# Altium schematic sheet AEI FX300 - Controller.SchDoc("AEI FX300 - Controller")
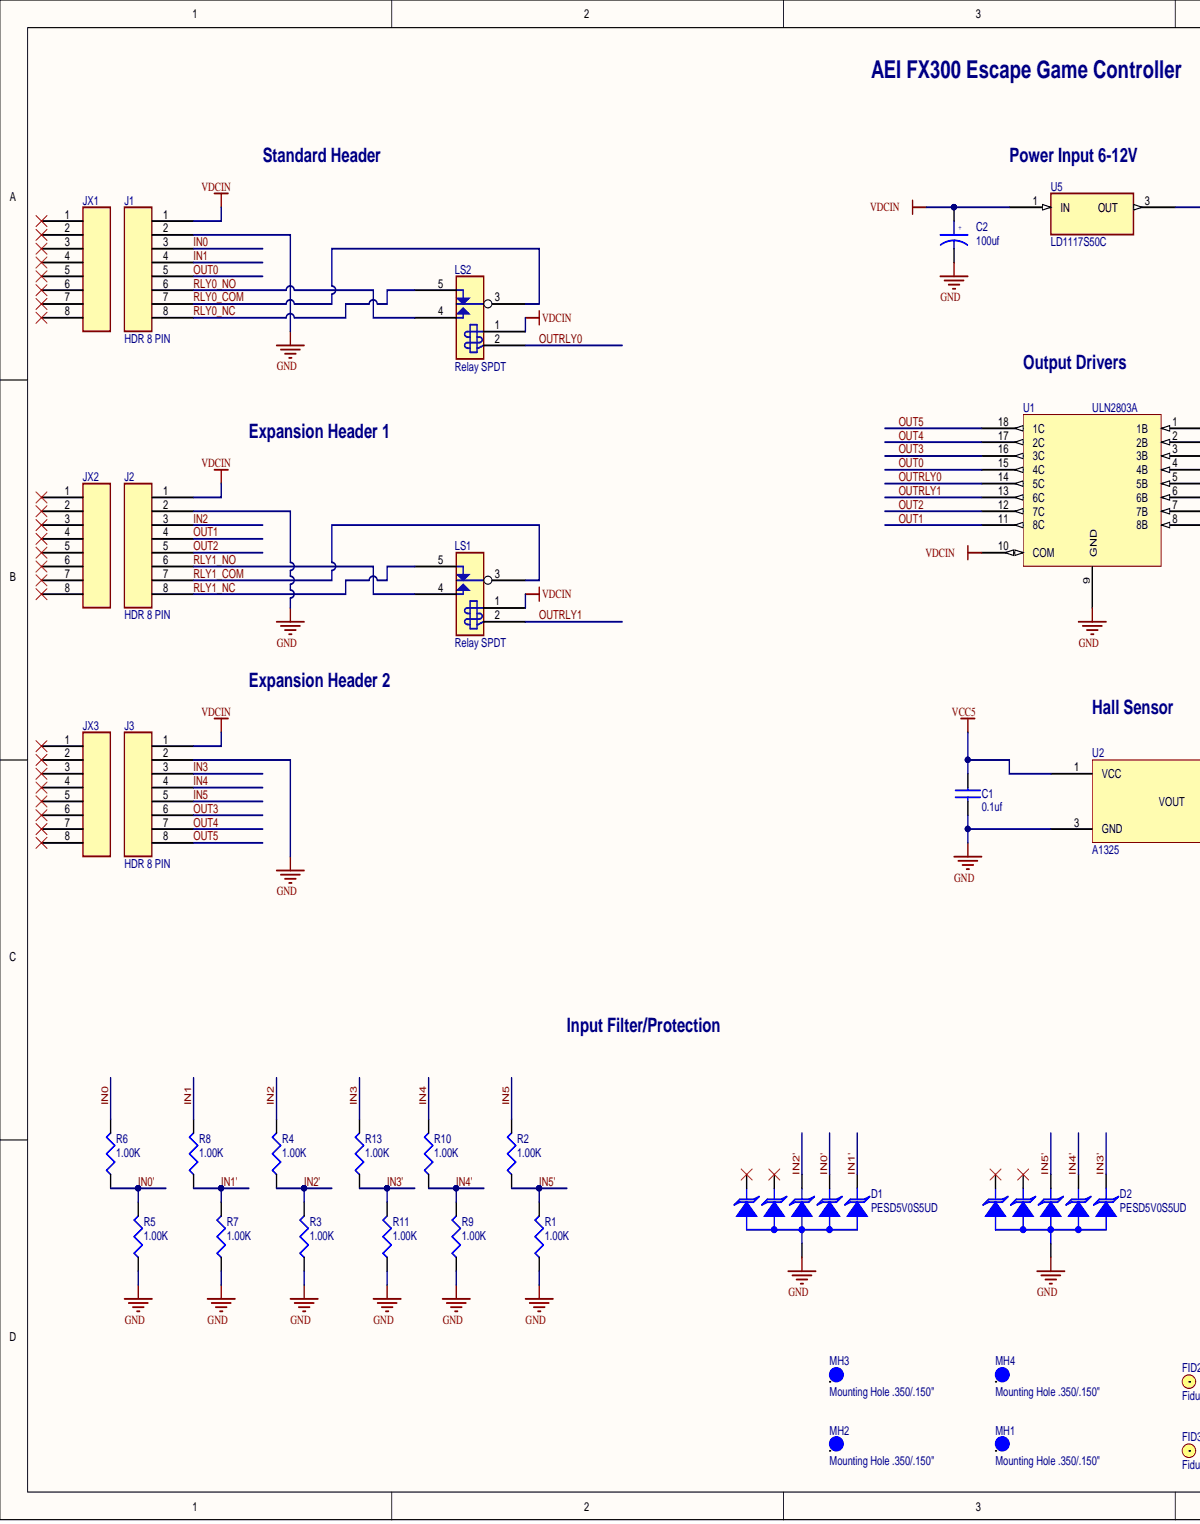
[diagram p0: AEI FX300 - Controller.SchDoc, left]
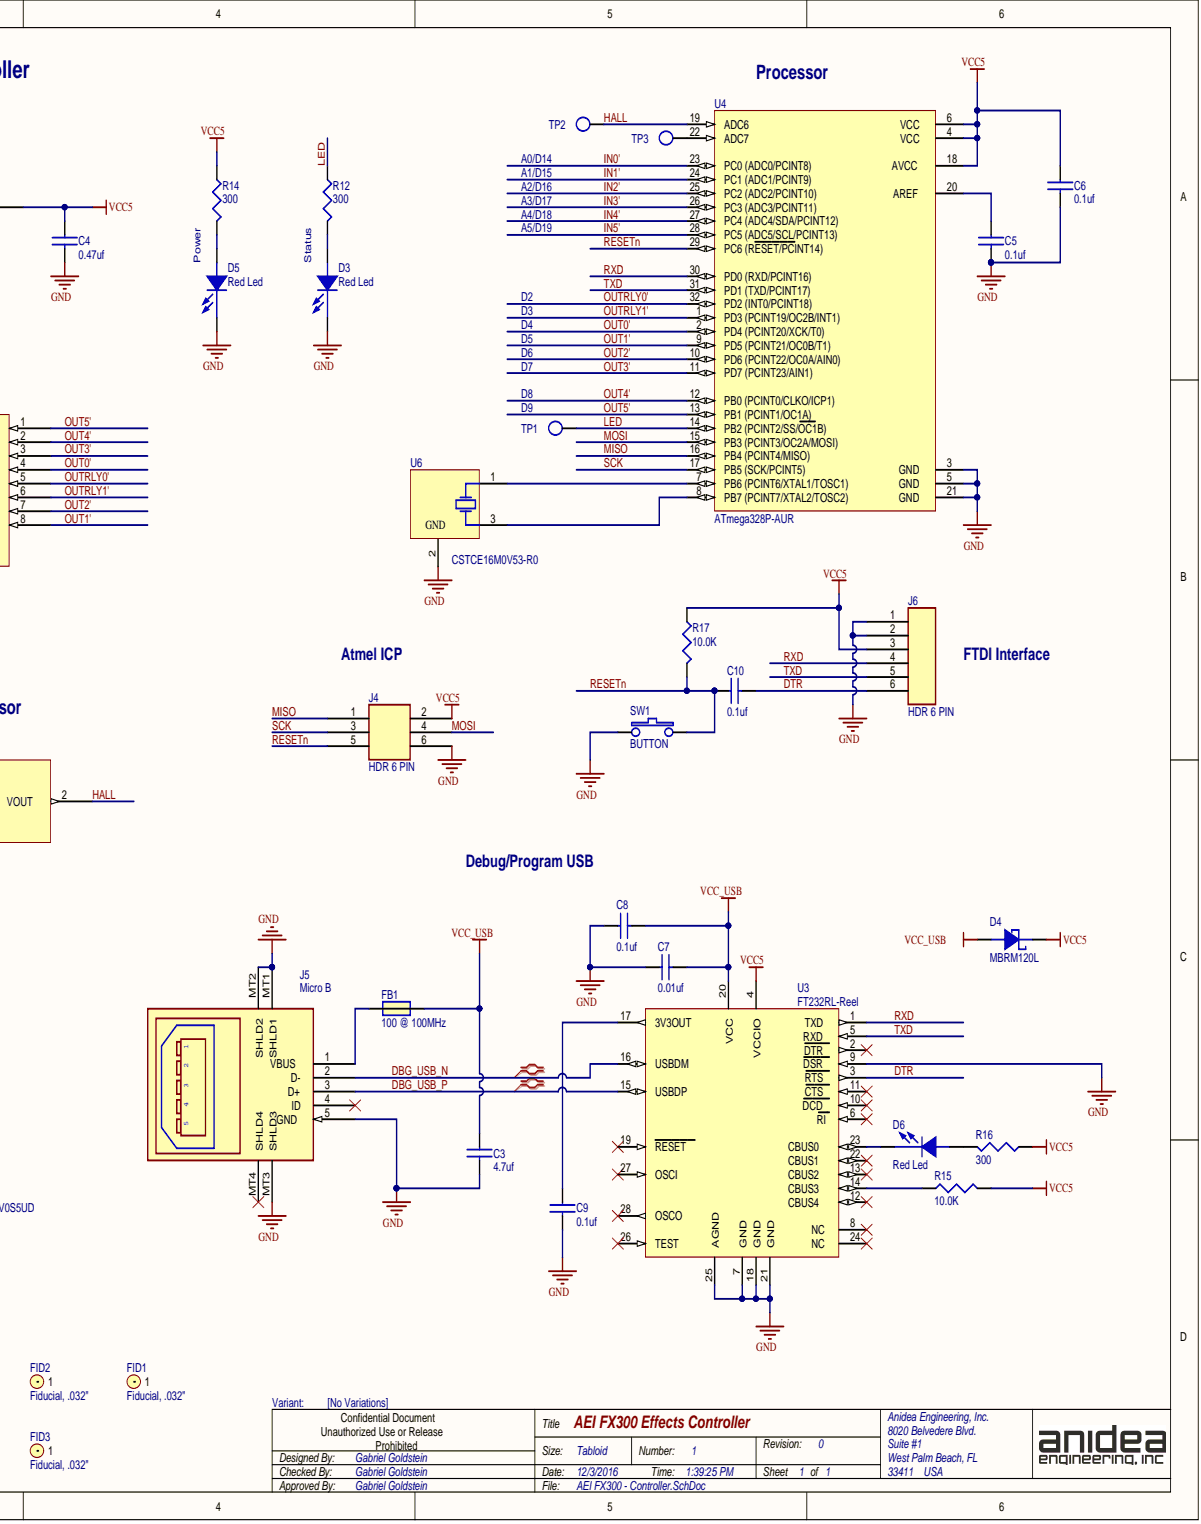
[diagram p1: AEI FX300 - Controller.SchDoc, right]

## Parts
C1  0.1uf  [SM/C_0603]
C2  100uf  [SM/C_6.3X5.5]
C3  4.7uf  [SM/C_0603]
C4  0.47uf  [SM/C_0603]
C5  0.1uf  [SM/C_0603]
C6  0.1uf  [SM/C_0603]
C7  0.01uf  [SM/C_0603]
C8  0.1uf  [SM/C_0603]
C9  0.1uf  [SM/C_0603]
C10  0.1uf  [SM/C_0603]
D1  PESD5V0S5UD  [SOT457]
D2  PESD5V0S5UD  [SOT457]
D3  Red Led  [SM/D_1206]
D4  MBRM120L  [POWERMITE]
D5  Red Led  [SM/D_1206]
D6  Red Led  [SM/D_1206]
FB1  100 @ 100MHz  [SM/I_0603]
FID1  Fiducial, .032"  [FID32]  (no BOM)
FID2  Fiducial, .032"  [FID32]  (no BOM)
FID3  Fiducial, .032"  [FID32]  (no BOM)
J1  HDR 8 PIN  [HDR/8/5.08MM/RA/1836244]
J2  HDR 8 PIN  [HDR/8/5.08MM/RA/1836244]
J3  HDR 8 PIN  [HDR/8/5.08MM/RA/1836244]
J4  HDR 6 PIN  [HDR/2X3/.1]
J5  Micro B  [USB/10118192-0001LF]
J6  HDR 6 PIN  [HDR/6/.1]
JX1  HDR 8 PIN  (value not drawn)
JX2  HDR 8 PIN  (value not drawn)
JX3  HDR 8 PIN  (value not drawn)
LS1  Relay SPDT  [RELAY/812H]
LS2  Relay SPDT  [RELAY/812H]
MH1  Mounting Hole .350/.150"  [MH.350/.150in]  (no BOM)
MH2  Mounting Hole .350/.150"  [MH.350/.150in]  (no BOM)
MH3  Mounting Hole .350/.150"  [MH.350/.150in]  (no BOM)
MH4  Mounting Hole .350/.150"  [MH.350/.150in]  (no BOM)
R1  1.00K  [SM/R_0603]
R2  1.00K  [SM/R_0603]
R3  1.00K  [SM/R_0603]
R4  1.00K  [SM/R_0603]
R5  1.00K  [SM/R_0603]
R6  1.00K  [SM/R_0603]
R7  1.00K  [SM/R_0603]
R8  1.00K  [SM/R_0603]
R9  1.00K  [SM/R_0603]
R10  1.00K  [SM/R_0603]
R11  1.00K  [SM/R_0603]
R12  300  [SM/R_0603]
R13  1.00K  [SM/R_0603]
R14  300  [SM/R_0603]
R15  10.0K  [SM/R_0603]
R16  300  [SM/R_0603]
R17  10.0K  [SM/R_0603]
SW1  BUTTON  [SWITCH4/PTS525_SM15]
TP1  TP  [VIA18]  (no BOM)
TP2  TP  [VIA18]  (no BOM)
TP3  TP  [VIA18]  (no BOM)
U1  ULN2803A  [SOIC18_12mmx8mm]
U2  A1325  [SOT23W]
U3  FT232RL-Reel  [SSOP-28_L]
U4  ATmega328P-AUR  [32A_M]
U5  LD1117S50C  [SOT223]
U6  CSTCE16M0V53-R0  [MURA-CSTCE_V-3_V]

## Nets
GND: C1.2 C2.2 C3.2 C4.2 C5.2 C6.2 C7.1 C8.1 C9.2 D1.2 D2.2 D3.2 D5.2 J1.2 J2.2 J3.2 J4.6 J5.5(GND) J5.MT1(SHLD1) J5.MT2(SHLD2) J5.MT3(SHLD3) J6.1 J6.2 R1.1 R3.1 R5.1 R7.1 R9.1 R11.1 SW1.1 U1.9(GND) U2.3 U3.7(GND) U3.9(DSR) U3.18(GND) U3.21(GND) U3.25(AGND) U4.3(GND) U4.5(GND) U4.21(GND) U5.2 U5.4 U6.2
VCC5: C1.1 C4.1 C6.1 D4.2 J4.2 J6.3 R14.1 R15.2 R16.1 R17.1 U2.1 U3.4(VCCIO) U4.4(VCC) U4.6(VCC) U4.18(AVCC) U5.3
VCC_USB: C3.1 C7.2 C8.2 D4.1 FB1.1 U3.20(VCC)
VDCIN: C2.1 J1.1 J2.1 J3.1 LS1.1 LS2.1 U1.10(COM) U5.1
DBG_USB_N: J5.2(D-) U3.16(USBDM)
DBG_USB_P: J5.3(D+) U3.15(USBDP)
DTR: C10.2 J6.6 U3.3(RTS)
HALL: TP2.1 U2.2 U4.19(ADC6)
IN0: J1.3 R6.2
IN0': D1.5 R5.2 R6.1 U4.23(PC0_(ADC0/PCINT8))
IN1: J1.4 R8.2
IN1': D1.6 R7.2 R8.1 U4.24(PC1_(ADC1/PCINT9))
IN2: J2.3 R4.2
IN2': D1.4 R3.2 R4.1 U4.25(PC2_(ADC2/PCINT10))
IN3: J3.3 R13.2
IN3': D2.6 R11.2 R13.1 U4.26(PC3_(ADC3/PCINT11))
IN4: J3.4 R10.2
IN4': D2.5 R9.2 R10.1 U4.27(PC4_(ADC4/SDA/PCINT12))
IN5: J3.5 R2.2
IN5': D2.4 R1.2 R2.1 U4.28(PC5_(ADC5/SCL/PCINT13))
LED: R12.1 TP1.1 U4.14(PB2_(PCINT2/SS/OC1B))
MISO: J4.1 U4.16(PB4_(PCINT4/MISO))
MOSI: J4.4 U4.15(PB3_(PCINT3/OC2A/MOSI))
OUT0: J1.5 U1.15(4C)
OUT0': U1.4(4B) U4.2(PD4_(PCINT20/XCK/T0))
OUT1: J2.4 U1.11(8C)
OUT1': U1.8(8B) U4.9(PD5_(PCINT21/OC0B/T1))
OUT2: J2.5 U1.12(7C)
OUT2': U1.7(7B) U4.10(PD6_(PCINT22/OC0A/AIN0))
OUT3: J3.6 U1.16(3C)
OUT3': U1.3(3B) U4.11(PD7_(PCINT23/AIN1))
OUT4: J3.7 U1.17(2C)
OUT4': U1.2(2B) U4.12(PB0_(PCINT0/CLKO/ICP1))
OUT5: J3.8 U1.18(1C)
OUT5': U1.1(1B) U4.13(PB1_(PCINT1/OC1A))
OUTRLY0: LS2.2 U1.14(5C)
OUTRLY0': U1.5(5B) U4.32(PD2_(INT0/PCINT18))
OUTRLY1: LS1.2 U1.13(6C)
OUTRLY1': U1.6(6B) U4.1(PD3_(PCINT19/OC2B/INT1))
RESETN: C10.1 J4.5 R17.2 SW1.2 U4.29(PC6_(RESET/PCINT14))
RLY0_COM: J1.7 LS2.3
RLY0_NC: J1.8 LS2.5
RLY0_NO: J1.6 LS2.4
RLY1_COM: J2.7 LS1.3
RLY1_NC: J2.8 LS1.5
RLY1_NO: J2.6 LS1.4
RXD: J6.4 U3.1(TXD) U4.30(PD0_(RXD/PCINT16))
SCK: J4.3 U4.17(PB5_(SCK/PCINT5))
TXD: J6.5 U3.5(RXD) U4.31(PD1_(TXD/PCINT17))
NetC5_1: C5.1 U4.20(AREF)
NetC9_1: C9.1 U3.17(3V3OUT)
NetD1_1: D1.1
NetD1_3: D1.3
NetD2_1: D2.1
NetD2_3: D2.3
NetD3_1: D3.1 R12.2
NetD5_1: D5.1 R14.2
NetD6_1: D6.1 R16.2
NetD6_2: D6.2 U3.23(CBUS0)
NetFB1_2: FB1.2 J5.1(VBUS)
NetFID1_1: FID1.1
NetFID2_1: FID2.1
NetFID3_1: FID3.1
NetJ5_4: J5.4(ID)
NetJ5_MT4: J5.MT4(SHLD4)
NetJX1_1: JX1.1
NetJX1_2: JX1.2
NetJX1_3: JX1.3
NetJX1_4: JX1.4
NetJX1_5: JX1.5
NetJX1_6: JX1.6
NetJX1_7: JX1.7
NetJX1_8: JX1.8
NetJX2_1: JX2.1
NetJX2_2: JX2.2
NetJX2_3: JX2.3
NetJX2_4: JX2.4
NetJX2_5: JX2.5
NetJX2_6: JX2.6
NetJX2_7: JX2.7
NetJX2_8: JX2.8
NetJX3_1: JX3.1
NetJX3_2: JX3.2
NetJX3_3: JX3.3
NetJX3_4: JX3.4
NetJX3_5: JX3.5
NetJX3_6: JX3.6
NetJX3_7: JX3.7
NetJX3_8: JX3.8
NetMH1_1: MH1.1
NetMH2_1: MH2.1
NetMH3_1: MH3.1
NetMH4_1: MH4.1
NetR15_1: R15.1 U3.14(CBUS3)
NetTP3_1: TP3.1 U4.22(ADC7)
NetU3_2: U3.2(DTR)
NetU3_6: U3.6(RI)
NetU3_8: U3.8(NC)
NetU3_10: U3.10(DCD)
NetU3_11: U3.11(CTS)
NetU3_12: U3.12(CBUS4)
NetU3_13: U3.13(CBUS2)
NetU3_19: U3.19(RESET)
NetU3_22: U3.22(CBUS1)
NetU3_24: U3.24(NC)
NetU3_26: U3.26(TEST)
NetU3_27: U3.27(OSCI)
NetU3_28: U3.28(OSCO)
NetU4_7: U4.7(PB6_(PCINT6/XTAL1/TOSC1)) U6.1
NetU4_8: U4.8(PB7_(PCINT7/XTAL2/TOSC2)) U6.3

## Library data of the parts
C1 C5 C6 C8-C10 = GRM188R71C104KA01: Cap, 0603, 0.1uf, 16V, 10%,; Mfg Part Number: GRM188R71C104KA01D; Database Table Name: AnideaParts; Mfg: Murata; Component Kind: Standard; ComponentLink1Description: Local; ComponentLink1URL: \\brak\public\pdf\Murata Cap PN.pdf; ComponentLink2Description: Web; Library Name: Anidea.SVNDbLib; Part Number: GRM188R71C104KA01; RoHS: True; Supplier 1: Digi-Key; Supplier Part Number 1: 490-1532-1-ND; Tolerance: 10%; Voltage: 16V
C2 = NACE101M16V6.3X5.5: Cap, SMT 6.3x5.5, 100uf, 16V, 20%, Al Ele; Mfg Part Number: UWX1C101MCL1GB; Database Table Name: AnideaParts; Mfg: Nichicon; Component Kind: Standard; ComponentLink1Description: Local; ComponentLink2Description: Web; Library Name: Anidea.SVNDbLib; Part Number: UWX1C101MCL1GB; RoHS: True; Tolerance: 20%; Voltage: 16V
C3 = GRM188R60J475KE19D: Cap, 0603, 4.7uf , 6.3V, 10%, X5R; Database Table Name: AnideaParts; Mfg: Murata; Component Kind: Standard; ComponentLink1Description: Local; ComponentLink1URL: \\brak\public\pdf\Murata Cap PN.pdf; ComponentLink2Description: Web; Library Name: Anidea.SVNDbLib; Mfg Part Number: GRM188R60J475KE19D; Part Number: GRM188R60J475KE19D; RoHS: True; Supplier 1: Digi-Key; Supplier Part Number 1: 490-3297-1-ND; Tolerance: 10%; Voltage: 6.3V
C4 = GRM188R61A474KA61: Cap, 0603, 0.47uf, 10V, 10%, X5R; Mfg Part Number: GRM188R61A474KA61D; Database Table Name: AnideaParts; Mfg: Murata; Component Kind: Standard; ComponentLink1Description: Local; ComponentLink1URL: \\newbrak\public\pdf\Murata Cap PN.pdf; ComponentLink2Description: Web; Library Name: Anidea.SVNDbLib; Part Number: GRM188R61A474KA61; RoHS: True; Supplier 1: Digi-Key; Supplier 2: TDK Corporation; Supplier 3: Digi-Key; Supplier Part Number 1: 490-1539-1-ND; Supplier Part Number 2: C1608X5R1A474K080AA; Supplier Part Number 3: 445-1320-1-ND; Tolerance: 10%; Voltage: 10V
C7 = GRM188R71H103KA01: Cap, 0603, .01uf, 50V, 10%,; Mfg Part Number: GRM188R71H103KA01D; Database Table Name: AnideaParts; Mfg: Murata; Component Kind: Standard; ComponentLink1Description: Local; ComponentLink1URL: \\brak\public\pdf\Murata Cap PN.pdf; ComponentLink2Description: Web; Library Name: Anidea.SVNDbLib; Part Number: GRM188R71H103KA01; RoHS: True; Supplier 1: Digi-Key; Supplier Part Number 1: 490-1512-1-ND; Tolerance: 10%; Voltage: 50V
D1 D2 = PESD5V0S5UD: TVS, ESD, 5 Diode Array, 5.0V, 30KV Pk, Unidirectional, SMT; Mfg Part Number: PESD5V0S5UD,115; Database Table Name: AnideaParts; Mfg: NXP; Rating: 200W; Component Kind: Standard; ComponentLink1Description: Local; ComponentLink1URL: \\newbrak\public\pdf\NXP PESDXS5UD_SER ESD ARRAY x5.pdf; ComponentLink2Description: Web; ComponentLink2URL: http://www.nxp.com/documents/data_sheet/PESDXS5UD_SER.pdf; Library Name: Anidea.SVNDbLib; Part Number: PESD5V0S5UD; RoHS: True; Supplier 1: Digi-Key; Supplier Part Number 1: 568-4142-1-ND; Voltage: 5.0V
D3 D5 D6 = BR1101W: Led, Red, 1206, Flat Top; Database Table Name: AnideaParts; Mfg: Stanley; Component Kind: Standard; ComponentLink1Description: Local; ComponentLink1URL: \\brak\public\pdf\Stanley 1101W.pdf; ComponentLink2Description: Web; Library Name: Anidea.SVNDbLib; Mfg Part Number: BR1101W; ModifiedDate: 12/3/2016; Part Number: BR1101W; RoHS: False; Supplier 1: Digi-Key; Supplier Part Number 1: 404-1042-1-ND
D4 = MBRM120L: Diode, Schottky, 1A, 20V; Mfg Part Number: MBRM120LT1G; Database Table Name: AnideaParts; Mfg: On Semi; Component Kind: Standard; ComponentLink1Description: Local; ComponentLink1URL: \\newbrak\public\pdf\OnSemi MBRM120L.pdf; ComponentLink2Description: Web; Library Name: Anidea.SVNDbLib; Part Number: MBRM120L; Rating: 1A; RoHS: True; Supplier 1: Digi-Key; Supplier Part Number 1: MBRM120LT1GOSCT-ND
FB1 = BLM18KG101TN1: EMI Bead, 0603, 100 OHM @ 100MHz, low DCR, Ferrite; Mfg Part Number: BLM18KG101TN1D; Database Table Name: AnideaParts; Mfg: Murata; Rating: 3A; Component Kind: Standard; ComponentLink1Description: Local; ComponentLink1URL: \\newbrak\Public\pdf\Murata BLM18K Ferrite Bead.pdf; ComponentLink2Description: Web; ComponentLink2URL: http://search.murata.co.jp/Ceramy/image/img/PDF/ENG/L0110S0100BLM18K.pdf; Library Name: Anidea.SVNDbLib; Part Number: BLM18KG101TN1; RoHS: True; Supplier 1: Digi-Key; Supplier 2: Murata; Supplier Part Number 1: 490-5448-1-ND; Supplier Part Number 2: BLM18KG101TN1D
FID1-FID3 = FID32: Fiducual, .032" Pad; Database Table Name: AnideaParts; ComponentLink1Description: Local; ComponentLink1URL: \\newbrak\public\pdf\; ComponentLink2Description: Web; Library Name: Anidea.SVNDbLib; Part Number: FID32; RoHS: False
J1-J3 = 1836244: Connector, Terminal Block, SIP, 8 pin, 5.08mm, Female, RA; Database Table Name: AnideaParts; Mfg: Phoenix Contact; Component Kind: Standard; ComponentLink1Description: Local; ComponentLink1URL: \\newbrak\public\pdf\Phoenix Contact 1836244.pdf; ComponentLink2Description: Web; ComponentLink2URL: http://eshop.phoenixcontact.com/phoenix/pdf.do?UID=1836244&general=usen; Library Name: Anidea.SVNDbLib; Mfg Part Number: 1836244; Part Number: 1836244; RoHS: True; Supplier 2: Mouser; Supplier Part Number 2: 651-1836244
J4 = PBC03DAAN: Header, Dual Row, 6 pin .1"; Database Table Name: AnideaParts; Mfg: Sullins Electronics; Component Kind: Standard; ComponentLink1Description: Local; ComponentLink1URL: \\newbrak\public\pdf\Sullins .1in Header.pdf; ComponentLink2Description: Web; ComponentLink2URL: http://www.sullinscorp.com/catalogs/97_Page78-79_HEADER_.100_2.54mm_MALE_BREAKAWAY.pdf; Library Name: Anidea.SVNDbLib; Mfg Part Number: PBC03DAAN; Part Number: PBC03DAAN; RoHS: True; Supplier 1: Digi-Key; Supplier Part Number 1: S2011E-03-ND
J5 = 10118192-0001LF: Connector, USB, Micro-B, Horizontal, SMT; Database Table Name: AnideaParts; Mfg: Amphenol FCI; Component Kind: Standard; ComponentLink1Description: Local; ComponentLink1URL: \\newbrak\public\pdf\Amphenol FCI 10118192.pdf; ComponentLink2Description: Web; ComponentLink2URL: http://portal.fciconnect.com/Comergent//fci/drawing/10118192.pdf; Library Name: Anidea.SVNDbLib; Mfg Part Number: 10118192-0001LF; Part Number: 10118192-0001LF; RoHS: True; Supplier 1: Digi-Key; Supplier Part Number 1: 609-4613-1-ND
J6 = PBC06SAAN: Header, SIP, 6 pin, .1"; Database Table Name: AnideaParts; Mfg: Sullins Electronics; Component Kind: Standard; ComponentLink1Description: Local; ComponentLink1URL: \\newbrak\public\pdf\Sullins .1in Header.pdf; ComponentLink2Description: Web; ComponentLink2URL: http://www.sullinscorp.com/catalogs/97_Page78-79_HEADER_.100_2.54mm_MALE_BREAKAWAY.pdf; Library Name: Anidea.SVNDbLib; Mfg Part Number: PBC06SAAN; Part Number: PBC06SAAN; RoHS: True; Supplier 1: Digi-Key; Supplier Part Number 1: S1011E-06-ND
JX1-JX3 = 1836134: Connector, Terminal Block, SIP, 8 pin, 5.08mm, Male, RA; Database Table Name: AnideaParts; Mfg: Phoenix Contact; Component Kind: Standard; ComponentLink1Description: Local; ComponentLink1URL: \\newbrak\public\pdf\Phoenix Contact 1836244.pdf; ComponentLink2Description: Web; ComponentLink2URL: http://www.digikey.com/product-detail/en/phoenix-contact/1836134/277-8863-ND/2527989; Library Name: Anidea.SVNDbLib; Mfg Part Number: 1836134; Part Number: 1836134; RoHS: True; Supplier 1: Digikey; Supplier Part Number 1: 277-8863-ND
LS1 LS2 = JS1-5V: Relay, SPDT, 5V Coil, PCB; Mfg Part Number: JS1-5V-F; Database Table Name: AnideaParts; Mfg: Panasonic; Rating: 10A; Component Kind: Standard; ComponentLink1Description: Local; ComponentLink1URL: \\newbrak\public\pdf\ds_61B05_en_js.pdf; ComponentLink2Description: Web; Library Name: Anidea.SVNDbLib; Part Number: JS1-5V; RoHS: True; Supplier 1: Digi-Key; Supplier Part Number 1: 255-2064-ND
MH1-MH4 = MH350/150: Mounting Hole, .350" Ring, .150" Hole; Database Table Name: AnideaParts; ComponentLink1Description: Local; ComponentLink1URL: \\newbrak\public\pdf\; ComponentLink2Description: Web; Library Name: Anidea.SVNDbLib; Part Number: MH350/150; RoHS: False
R1-R11 R13 = CRCW06031K00F: Resistor, 0603, 1.00K Ohm 1%; Mfg Part Number: CRCW06031K00FKEA; Database Table Name: AnideaParts; Mfg: Vishay; Rating: 1/10W; Component Kind: Standard; ComponentLink1Description: Local; ComponentLink1URL: \\newbrak\public\pdf\Vishay CRCW Resistors.pdf; ComponentLink2Description: Web; ComponentLink2URL: http://www.vishay.com/doc?20035; Library Name: Anidea.SVNDbLib; Part Number: CRCW06031K00F; RoHS: True; Supplier 1: Digi-Key; Supplier 2: Yageo; Supplier 3: Digi-Key; Supplier Part Number 1: 541-1.00KHCT-ND; Supplier Part Number 2: RC0603FR-071KL; Supplier Part Number 3: 311-1.00KHRCT-ND; Tolerance: 1%
R12 R14 R16 = CRCW0603300RF: Resistor, 0603, 300 Ohm 1%; Mfg Part Number: CRCW0603300RFKEA; Database Table Name: AnideaParts; Mfg: Vishay; Rating: 1/10W; Component Kind: Standard; ComponentLink1Description: Local; ComponentLink1URL: \\newbrak\public\pdf\Vishay CRCW Resistors.pdf; ComponentLink2Description: Web; Library Name: Anidea.SVNDbLib; Part Number: CRCW0603300RF; RoHS: True; Supplier 1: Digi-key; Supplier Part Number 1: 541-300HCT-ND; Tolerance: 1%
R15 R17 = CRCW060310K0F: Resistor, 0603, 10.0K Ohm 1%; Mfg Part Number: CRCW060310K0FKEA; Database Table Name: AnideaParts; Mfg: Vishay; Rating: 1/10W; Component Kind: Standard; ComponentLink1Description: Local; ComponentLink2Description: Web; ComponentLink2URL: http://www.vishay.com/doc?20035; Library Name: Anidea.SVNDbLib; Part Number: CRCW060310K0F; RoHS: True; Supplier 1: Digi-Key; Supplier 2: Yageo; Supplier 3: Digi-Key; Supplier Part Number 1: 541-10.0KHCT-ND; Supplier Part Number 2: RC0603FR-0710KL; Supplier Part Number 3: 311-10.0KHRCT-ND; Tolerance: 1%
  R15: ComponentLink1URL: \\brak\public\pdf\Vishay CRCW Resistors.pdf
  R17: ComponentLink1URL: \\newbrak\public\pdf\Vishay CRCW Resistors.pdf
SW1 = PTS525SM15SMTR2: Switch, Pushbutton, 5.2mmx5.2mm,Tact, SMT; Mfg Part Number: PTS525SM15SMTR2 LFS; Database Table Name: AnideaParts; Mfg: C&K; Component Kind: Standard; ComponentLink1Description: Local; ComponentLink1URL: \\newbrak\public\pdf\C&K PTS Series.pdf; ComponentLink2Description: Web; ComponentLink2URL: http://www.ckswitches.com/media/1467/pts525.pdf; Library Name: Anidea.SVNDbLib; Part Number: PTS525SM15SMTR2; RoHS: True; Supplier 1: Digi-Key; Supplier Part Number 1: CKN9104CT-ND
TP1-TP3 = TP18: Testpoint, 18mil Hole, No part, just via; Database Table Name: AnideaParts; ComponentLink1Description: Local; ComponentLink2Description: Web; Library Name: Anidea.SVNDbLib; Part Number: TP18; RoHS: False
U1 = ULN2803A: IC, Driver, 8 NPN Transistor; Mfg Part Number: ULN2803ADWG4; Database Table Name: AnideaParts; Mfg: TI; Component Kind: Standard; ComponentLink1Description: Local; ComponentLink1URL: \\newbrak\public\pdf\TI  ULN2803A.pdf; ComponentLink2Description: Web; ComponentLink2URL: http://www.ti.com/lit/ds/symlink/uln2803a.pdf; Library Name: Anidea.SVNDbLib; Part Number: ULN2803A; RoHS: True; Supplier 1: Digi-Key; Supplier Part Number 1: 296-36073-5-ND
U2 = A1325: Sensor, Hall,  Linear 4.5V - 5.5V, Analog; Mfg Part Number: A1325LLHLT-T; Database Table Name: AnideaParts; Mfg: Allegro; Component Kind: Standard; ComponentLink1Description: Local; ComponentLink1URL: \\newbrak\public\pdf\Allegro A1325.pdf; ComponentLink2Description: Web; Library Name: Anidea.SVNDbLib; Part Number: A1325; RoHS: True; Supplier 1: Digi-Key; Supplier Part Number 1: 620-1401-1-ND
U3 = CMP-0078-00029-3: USB UART Asynchronous Serial Data Transfer Chip, 28-pin SSOP, Tape and Reel; Manufacturer: FTDI; Component Kind: Standard; ComponentLink1Description: Manufacturer URL; ComponentLink1URL: http://www.ftdichip.com/; ComponentLink2Description: Datasheet; ComponentLink2URL: http://www.ftdichip.com/Support/Documents/DataSheets/ICs/DS_FT232R.pdf; DatasheetVersion: ver 2.10, Mar-2012; Library Name: Altium Content Vault; Mfg Part Number: FT232RL-Reel; PackageDescription: 28-Pin Small Outline Package 0.65 mm Pitch; PackageReference: SSOP-28; PackageVersion: Mar-12; RevisionNote: DatasheetVersion updated to 2.10 Mar-2012
U4 = CMP-0095-00267-2: 8-bit AVR Microcontroller, 32KB Flash, 1KB EEPROM, 2KB SRAM, 32-pin TQFP, Industrial Grade (-40°C to 85°C), Reel; Code_JEDEC: MS-026-ABA; Component Kind: Standard; ComponentLink1Description: Manufacturer URL; ComponentLink1URL: http://www.atmel.com/; ComponentLink2Description: ATmega48A/48PA/88A/88PA/168A/168PA/328/328P Datasheet; ComponentLink2URL: http://www.atmel.com/Images/Atmel-8271-8-bit-AVR-Microcontroller-ATmega48A-48PA-88A-88PA-168A-168PA-328-328P_datasheet_Complete.pdf; ComponentLink3Description: ATmega48A/48PA/88A/88PA/168A/168PA/328/328P Summary; ComponentLink3URL: http://www.atmel.com/dyn/resources/prod_documents/8271S.pdf; DatasheetVersion: revD, May-2011; Library Name: Altium Content Vault; Mfg Part Number: ATmega328P-AUR; PackageDescription: 32-Lead, 7x7x1.0 mm Body, 0.8mm Lead Pitch, Thin Profile Plastic Quad Flat Package (TQFP); PackageReference: 32A; PackageVersion: revC, Oct-2010; RevisionNote: DatasheetVersion & PackageVersion updated. URLs added.
U5 = L5150BNTR: Regulator, 5V Fixed, 150mA, LDO, SOT223; Mfg Part Number: LD1117S50CTR; Database Table Name: AnideaParts; Mfg: ST; Component Kind: Standard; ComponentLink1Description: Local; ComponentLink2Description: Web; ComponentLink2URL: http://www.st.com/web/en/resource/technical/document/datasheet/CD00229419.pdf; Library Name: Anidea.SVNDbLib; Part Number: LD1117S50CTR; RoHS: True; Supplier 1: Digi-Key; Supplier Part Number 1: 497-1243-1-ND
U6 = CMP-0588-00001-2: Ceramic Resonator, 16 MHz with Built-in Capacitance, Thickness Expander Mode, Initial Frequency Tolerance +/- 0.5%, Load Capacity 15 pF, -20 to 80 degC, 3.2 x 1.3 x 1 mm SMD, RoHS, Reel; Manufacturer: Murata; Load Capacity: 15 pF; Oscillating Frequency: 16 MHz; Component Kind: Standard; ComponentLink1Description: Manufacturer URL; ComponentLink1URL: http://www.murata.com/; ComponentLink2Description: Datasheet; ComponentLink2URL: http://www.murata.com/products/catalog/pdf/p16e.pdf; DatasheetVersion: Rev. P16E-22; Initial Frequency Tolerance: +/- 0.5%; Initial Tolerance: +/- 0.5%; Library Name: Altium Content Vault; Mfg Part Number: CSTCE16M0V53-R0; Mounting Technology: Surface Mount; Operating Temperature Range: -20 to 80 degC; PackageDescription: 3-Pin SMD, Body 3.2 x 1.3 mm, Pitch 0.95 mm; PackageReference: CSTCE_V-3; Packing: Reel; RoHS: TRUE
